# Altium schematic sheet power_supply.SchDoc(power_supply)
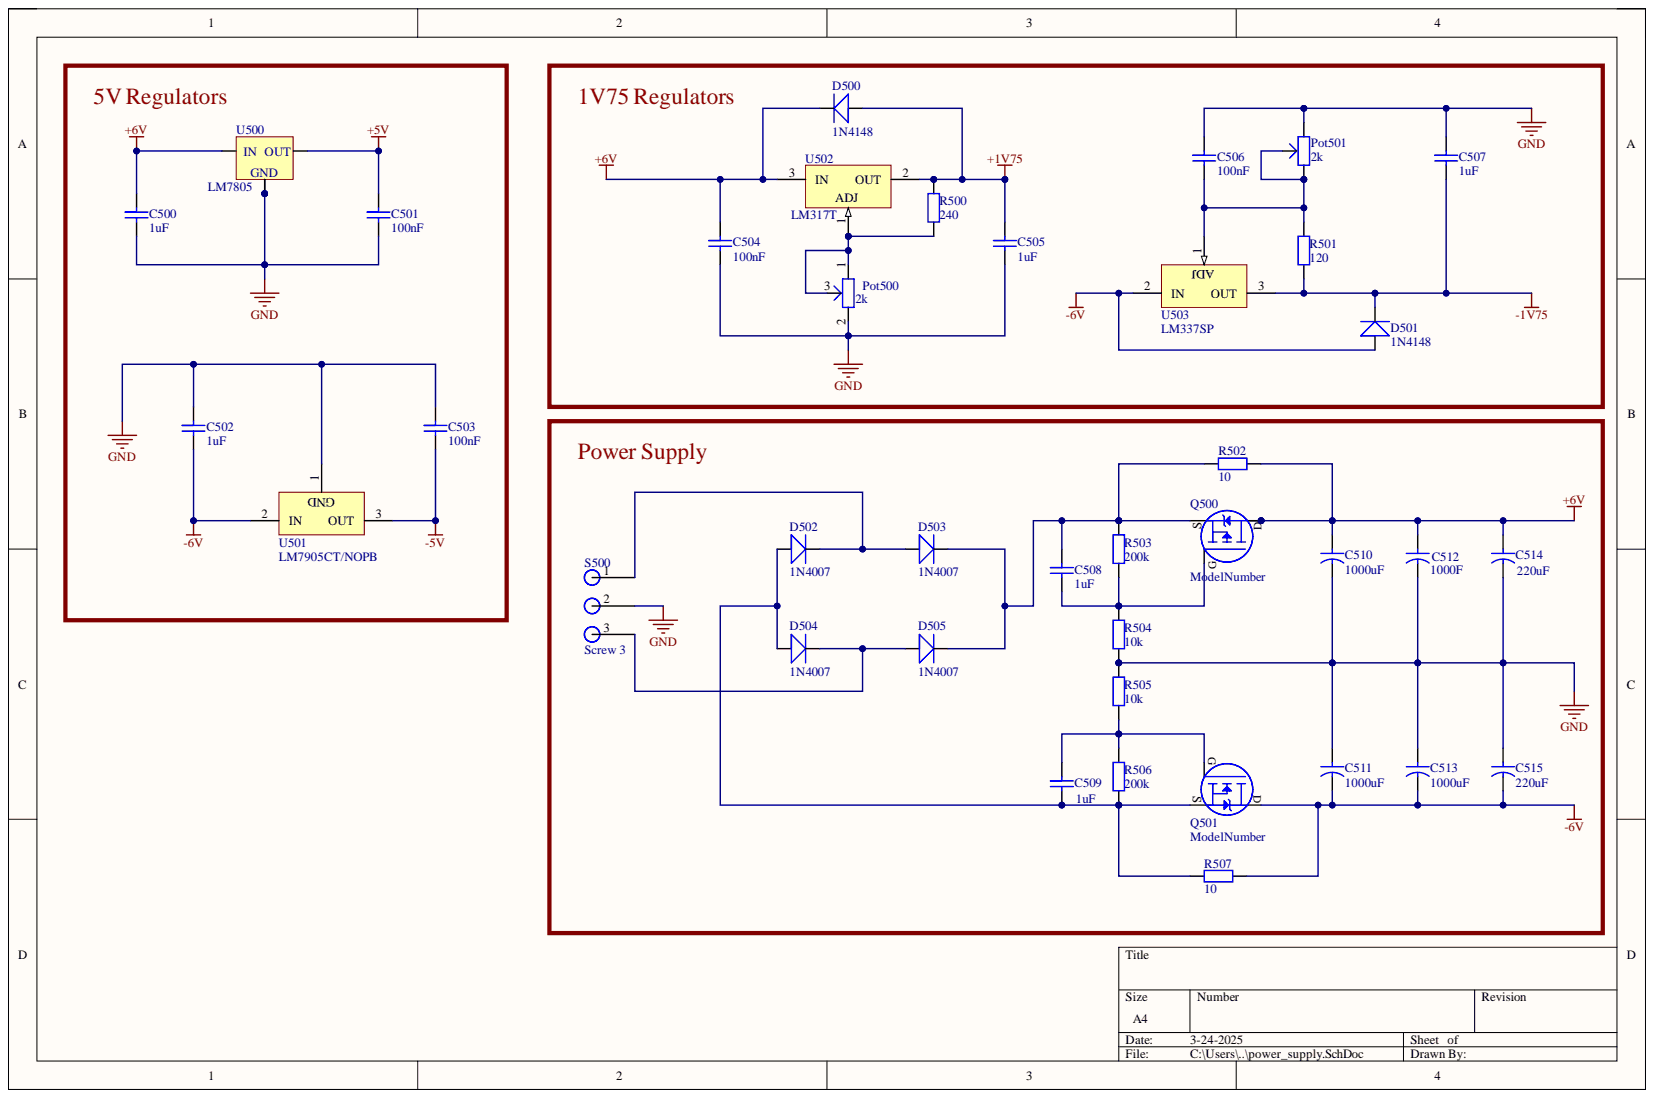
[diagram p0: power_supply.SchDoc]

## Parts
C500  Capacity: 1uF  [Cap-1]  (hidden comment: Cap)
C501  Capacity: 100nF  [Cap-1]  (hidden comment: Cap)
C502  Capacity: 1uF  [Cap-1]  (hidden comment: Cap)
C503  Capacity: 100nF  [Cap-1]  (hidden comment: Cap)
C504  Capacity: 100nF  [Cap-1]  (hidden comment: Cap)
C505  Capacity: 1uF  [Cap 20mm]  (hidden comment: Cap)
C506  Capacity: 100nF  [Cap-1]  (hidden comment: Cap)
C507  Capacity: 1uF  [Cap 20mm]  (hidden comment: Cap)
C508  value 1uF  [Cap-1]  (hidden comment: Cap)
C509  value 1uF  [Cap-1]  (hidden comment: Cap)
C510  Capacity: 1000uF  [Cap Lyt Ø18]  (hidden comment: Cap)
C511  Capacity: 1000uF  [Cap Lyt Ø18]  (hidden comment: Cap)
C512  Capacity: 1000F  [Cap Lyt Ø18]  (hidden comment: Cap)
C513  Capacity: 1000uF  [Cap Lyt Ø18]  (hidden comment: Cap)
C514  Capacity: 220uF  [Cap Lyt Ø8]  (hidden comment: Cap)
C515  Capacity: 220uF  [Cap Lyt Ø8]  (hidden comment: Cap)
D500  Model: 1N4148  [Diode 2 space]  (hidden comment: Diode DO-35)
D501  Model: 1N4148  [Diode 2 space]  (hidden comment: Diode DO-35)
D502  Model: 1N4007  [Diode 2 space]  (hidden comment: Diode DO-35)
D503  Model: 1N4007  [Diode 2 space]  (hidden comment: Diode DO-35)
D504  Model: 1N4007  [Diode 2 space]  (hidden comment: Diode DO-35)
D505  Model: 1N4007  [Diode 2 space]  (hidden comment: Diode DO-35)
Pot500  Resistance: 2k  [Pot trim slim high]  (hidden comment: Pot Trim)
Pot501  Resistance: 2k  [Pot trim slim high]  (hidden comment: Pot Trim)
Q500  ModelNum  Model: ModelNumber  [TO-220]
Q501  ModelNum  Model: ModelNumber  [TO-220]
R500  value 240  [AXIAL-0.4 PCBK]
R501  value 120  [AXIAL-0.4 PCBK]
R502  value 10  [AXIAL-0.4 PCBK]
R503  value 200k  [AXIAL-0.4 PCBK]
R504  value 10k  [AXIAL-0.4 PCBK]
R505  value 10k  [AXIAL-0.4 PCBK]
R506  value 200k  [AXIAL-0.4 PCBK]
R507  value 10  [AXIAL-0.4 PCBK]
S500  Screw 3  [Screw-3]
U500  LM7805  [TO-220]
U501  LM7905CT/NOPB  [NDE0003A]
U502  LM317T  [TO-220]
U503  LM337SP  [TO220]

## Nets
+1V75: C505.2 D500.1 R500.2 U502.2
+5V: C501.2 U500.3(OUT)
+6V: C500.2 C504.2 C510.1 C512.1 C514.1 D500.2 Q500.3 Q500.4 R502.1 U500.1(IN) U502.3
-1V75: C507.1 D501.2 R501.1 U503.3
-5V: C503.1 U501.3
-6V: C502.1 C511.2 C513.2 C515.2 D501.1 Q501.2 R507.1 U501.2 U503.2
GND: C500.1 C501.1 C502.2 C503.2 C504.1 C505.1 C506.2 C507.2 C510.2 C511.1 C512.2 C513.1 C514.2 C515.1 Pot500.2 Pot501.1 R504.1 R505.2 S500.2 U500.2 U500.4 U501.1
NetC506_1: C506.1 Pot501.2 Pot501.3 R501.2 U503.1
NetC508_1: C508.1 Q500.2(S) R503.1 R504.2
NetC508_2: C508.2 D503.2 D505.2 Q500.1 R502.2 R503.2
NetC509_1: C509.1 D502.1 D504.1 Q501.3 R506.1 R507.2
NetC509_2: C509.2 Q501.1 R505.1 R506.2
NetD502_2: D502.2 D503.1 S500.1
NetD504_2: D504.2 D505.1 S500.3
NetPot500_1: Pot500.1 Pot500.3 R500.1 U502.1

## Library data of the parts
C500-C504 C506 C508 C509 = Capacitor 1 space: Component Kind: Standard; Library Name: AAURacing.IntLib
C505 C507 = Capacitor big ceramic: Component Kind: Standard; Library Name: AAURacing.IntLib
C510-C515 = Capacitor electrolytic: Component Kind: Standard; Library Name: AAURacing.IntLib
Pot500 Pot501 = Pot Trim: Potentiometer; Component Kind: Standard; Library Name: AAURacing.IntLib
Q500 = MOSFET P-Channel: Component Kind: Standard; Library Name: AAURacing.SchLib
Q501 = MOSFET N-Channel: Component Kind: Standard; Library Name: AAURacing.IntLib
R500-R507 = Res PCBK: Resistor; Component Kind: Standard; LatestRevisionDate: 17-Jul-2002; LatestRevisionNote: Re-released for DXP Platform.; PackageReference: AXIAL-0.4; Published: 8-Jun-2000; Library Name: pcb_kursus_lib2020 (1).IntLib; Publisher: Altium Limited
U500 = Voltage Regulator: Component Kind: Standard; Library Name: AAURacing.IntLib
U501 = CMP-0062-02481-3: 3-Terminal Negative Regulator, 3-pin TO-220, Pb-Free; Manufacturer: Texas Instruments; CompType: LDO IC; PackageTopMarking: NSUZXYTTE#*LM7905CT; PowerWise: N; Component Kind: Standard; ComponentLink1Description: Datasheet URL; ComponentLink1URL: http://www.ti.com/general/docs/lit/getliterature.tsp?genericPartNumber=LM7905&fileType=pdf; Library Name: Altium Content Vault; PackageDescription: TO-220, Molded, 3 Lead, Straight; PackageReference: NDE0003A; PinCount: 3; RoHS: Y
U502 = CMP-0244-00444-1: 1.5A, 1.2V to 37V Adjustable Voltage Regulator, 3-Pin TO-220; Component Kind: Standard; ComponentLink1Description: Manufacturer URL; ComponentLink1URL: http://www.st.com; ComponentLink2Description: Datasheet; ComponentLink2URL: http://www.st.com/internet/com/TECHNICAL_RESOURCES/TECHNICAL_LITERATURE/DATASHEET/CD00000455.pdf; ComponentLink3Description: Package Specification; ComponentLink3URL: http://www.st.com/internet/com/TECHNICAL_RESOURCES/TECHNICAL_LITERATURE/PACKAGE_INFORMATION/CD00004649.pdf; DatasheetVersion: 1/11/2011; Library Name: Altium Content Vault; PackageDescription: TO, Thru-Hole, Vertical, Heatsink Mounted; 3 In-Line Leads; Pitch 2.54 mm; PackageReference: TO-220; PackageVersion: 2011
U503 = CMP-0244-00420-1: Three-Terminal Negative Voltage Regulator, 3-Pin TO-220; Component Kind: Standard; ComponentLink1Description: Manufacturer URL; ComponentLink1URL: http://www.st.com; ComponentLink2Description: Datasheet; ComponentLink2URL: http://www.st.com/internet/com/TECHNICAL_RESOURCES/TECHNICAL_LITERATURE/DATASHEET/CD00000468.pdf; ComponentLink3Description: Package Specification; ComponentLink3URL: http://www.st.com/internet/com/TECHNICAL_RESOURCES/TECHNICAL_LITERATURE/PACKAGE_INFORMATION/CD00004649.pdf; DatasheetVersion: 1/10/2011; Library Name: Altium Content Vault; PackageDescription: TO, Thru-Hole, Vertical, Heatsink Mounted; 3 In-Line Leads; Pitch 2.54 mm; PackageReference: TO220; PackageVersion: ver3.0
D500-D505 = Diode DO-35: Component Kind: Standard; Library Name: AAURacing.IntLib
S500 = Screw 3: Component Kind: Standard; Library Name: AAURacing.IntLib
